# Altium schematic sheet Memory.SchDoc(Memory)
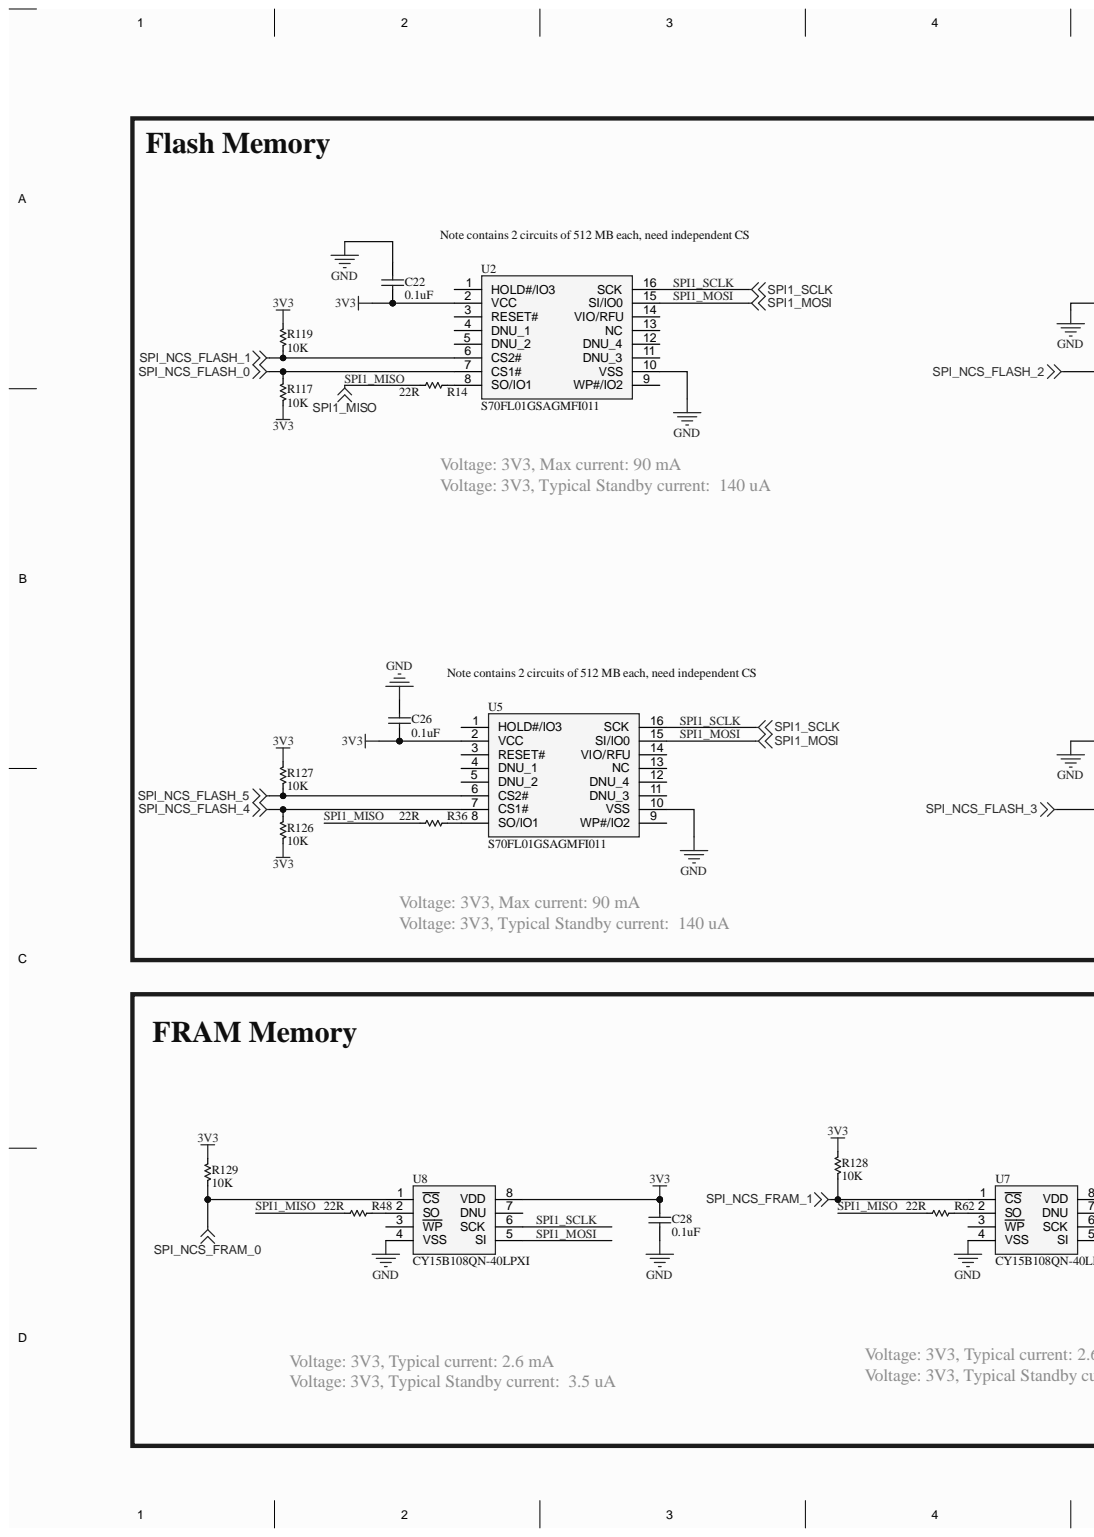
[diagram p0: Memory.SchDoc, left]
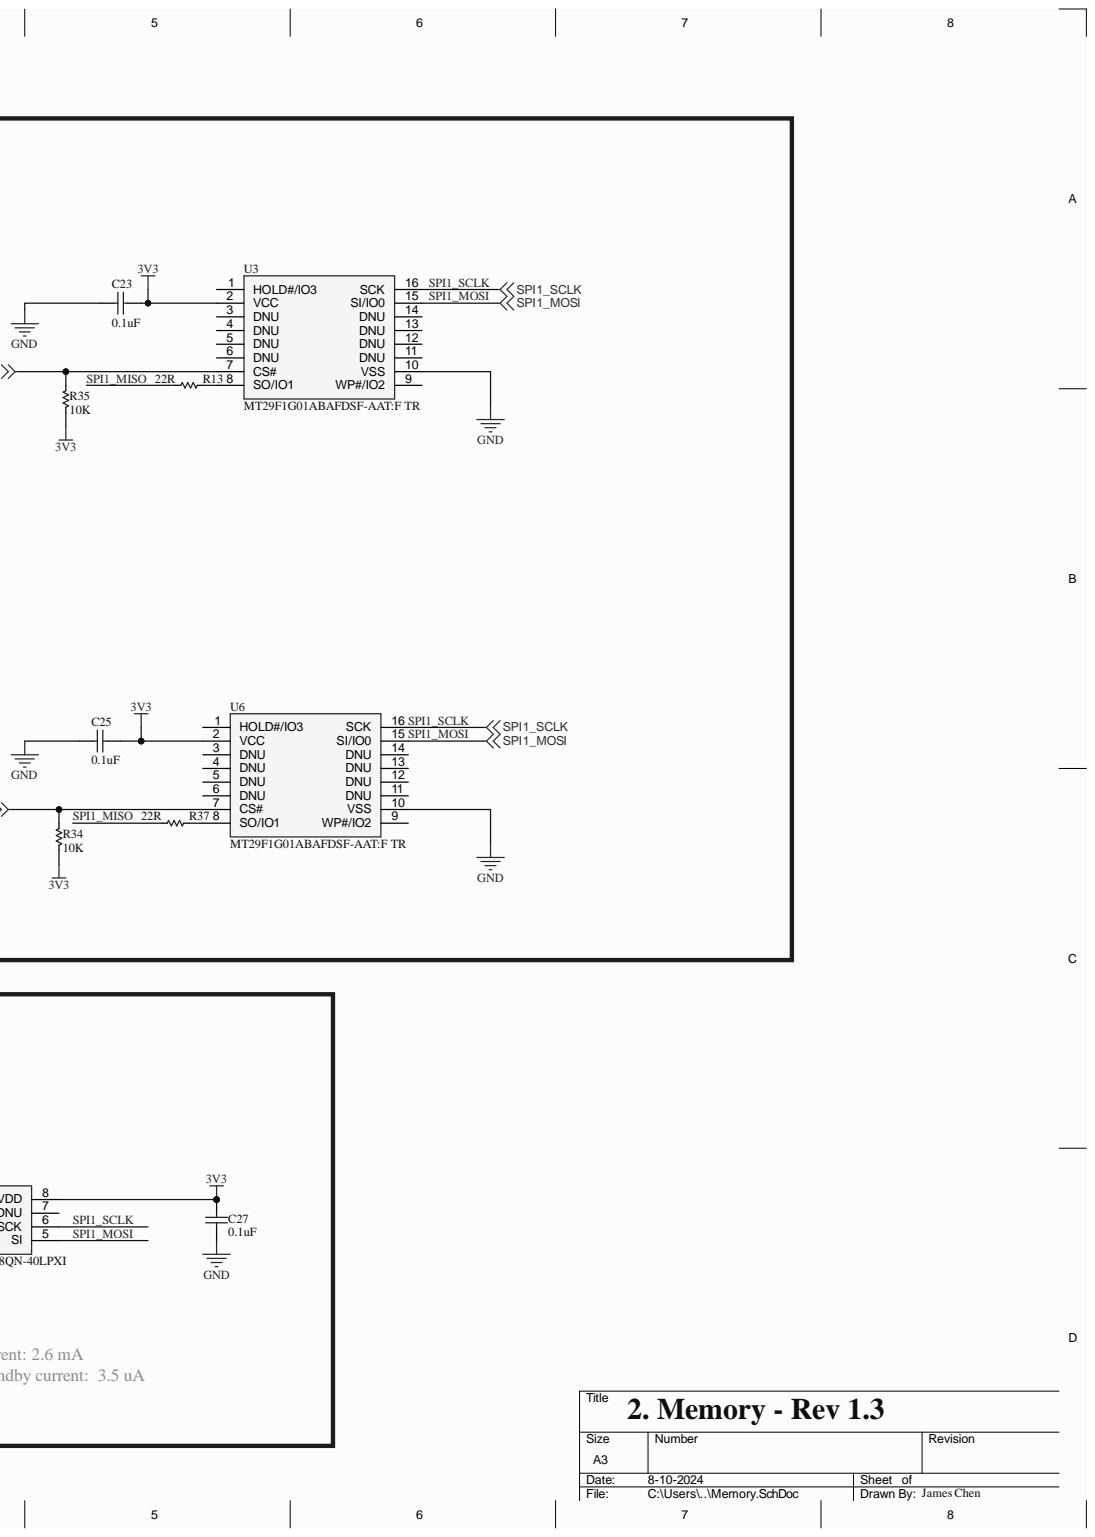
[diagram p1: Memory.SchDoc, right]

## Parts
C22  0.1uF  [0603]
C23  0.1uF  [0603]
C25  0.1uF  [0603]
C26  0.1uF  [0603]
C27  0.1uF  [0603]
C28  0.1uF  [0603]
R13  22R  [0603]
R14  22R  [0603]
R34  10K  [0603]
R35  10K  [0603]
R36  22R  [0603]
R37  22R  [0603]
R48  22R  [0603]
R62  22R  [0603]
R117  10K  [0603]
R119  10K  [0603]
R126  10K  [0603]
R127  10K  [0603]
R128  10K  [0603]
R129  10K  [0603]
U2  S70FL01GSAGMFI011  [U-S70FL01GSAGMFI011]
U3  MT29F1G01ABAFDSF-AAT:F TR  [U-MT29F1G01ABAFDSF-AAT:F TR]
U5  S70FL01GSAGMFI011  [U-S70FL01GSAGMFI011]
U6  MT29F1G01ABAFDSF-AAT:F TR  [U-MT29F1G01ABAFDSF-AAT:F TR]
U7  CY15B108QN-40LPXI  [U-CY15B108QN-40LPXI]
U8  CY15B108QN-40LPXI  [U-CY15B108QN-40LPXI]

## Nets
3V3: C22.1 C23.2 C25.2 C26.1 C27.1 C28.1 R34.1 R35.1 R117.1 R119.1 R126.1 R127.2 R128.1 R129.1 U2.2(VCC) U3.2(VCC) U5.2(VCC) U6.2(VCC) U7.8(VDD) U8.8(VDD)
GND: C22.2 C23.1 C25.1 C26.2 C27.2 C28.2 U2.10(VSS) U3.10(VSS) U5.10(VSS) U6.10(VSS) U7.4(VSS) U8.4(VSS)
SPI_NCS_FLASH_0: R117.2 U2.7(CS1#)
SPI_NCS_FLASH_1: R119.2 U2.6(CS2#)
SPI_NCS_FLASH_2: R35.2 U3.7(CS#)
SPI_NCS_FLASH_3: R34.2 U6.7(CS#)
SPI_NCS_FLASH_4: R126.2 U5.7(CS1#)
SPI_NCS_FLASH_5: R127.1 U5.6(CS2#)
SPI_NCS_FRAM_0: R129.2 U8.1(CS)
SPI_NCS_FRAM_1: R128.2 U7.1(CS)
SPI1_MISO: R13.1 R14.1 R36.1 R37.1 R48.1 R62.1
SPI1_MOSI: U2.15(SI/IO0) U3.15(SI/IO0) U5.15(SI/IO0) U6.15(SI/IO0) U7.5(SI) U8.5(SI)
SPI1_SCLK: U2.16(SCK) U3.16(SCK) U5.16(SCK) U6.16(SCK) U7.6(SCK) U8.6(SCK)
NetR13_2: R13.2 U3.8(SO/IO1)
NetR14_2: R14.2 U2.8(SO/IO1)
NetR36_2: R36.2 U5.8(SO/IO1)
NetR37_2: R37.2 U6.8(SO/IO1)
NetR48_2: R48.2 U8.2(SO)
NetR62_2: R62.2 U7.2(SO)

Not connected: U2 1(HOLD#/IO3) 3(RESET#) 4(DNU_1) 5(DNU_2) 9(WP#/IO2) 11(DNU_3) 12(DNU_4) 13(NC) 14(VIO/RFU); U3 1(HOLD#/IO3) 3(DNU) 4(DNU) 5(DNU) 6(DNU) 9(WP#/IO2) 11(DNU) 12(DNU) 13(DNU) 14(DNU); U5 1(HOLD#/IO3) 3(RESET#) 4(DNU_1) 5(DNU_2) 9(WP#/IO2) 11(DNU_3) 12(DNU_4) 13(NC) 14(VIO/RFU); U6 1(HOLD#/IO3) 3(DNU) 4(DNU) 5(DNU) 6(DNU) 9(WP#/IO2) 11(DNU) 12(DNU) 13(DNU) 14(DNU); U7 3(WP) 7(DNU); U8 3(WP) 7(DNU)

## Library data of the parts
C22 C23 C25-C28 = CC-100nF-25V-X7R-0603: 25V 100nF X7R ±10% 0603 Multilayer Ceramic Capacitors MLCC - SMD/SMT ROHS; Manufacturer: Murata Electronics; Manufacturer Part #: GCJ188R71E104KA12D; Max Exposed Voltage: 6; Rated Voltage: 25; Component Kind: Standard; ComponentLink1description: Datasheet; ComponentLink1URL: https://ds.yuden.co.jp/TYCOMPAS/ut/detail?pn=TMK105BJ104KV-F%20%20&u=M; ComponentLink2description: Manufacturer Website; ComponentLink2URL: https://www.yuden.co.jp/or/; Distributor: LCSC; Distributor Part #: C354391; Highest Rated Operating Temperature: 125; Lowest Rated Operating Temperature: -55; Part Description: 25V 100nF X7R ±10% 0603 Multilayer Ceramic Capacitors MLCC - SMD/SMT ROHS; Value: 0.1uF; Library Name: OBC Development Board.SchLib
R13 R14 R36 R37 R48 R62 = RT-22R0-F-1/16W-0603: 22 Ohms ±0.5% 0.063W, 1/16W Chip Resistor 0603 (1608 Metric) - Thin Film; Manufacturer: Susumu; Manufacturer Part #: RR0816Q-220-D; Component Kind: Standard; ComponentLink1description: Datasheet; ComponentLink1URL: https://www.vishay.com/docs/20035/dcrcwe3.pdf; ComponentLink2description: Manufacturer Website; ComponentLink2URL: https://www.vishay.com/; Distributor: Digikey; Distributor Part #: RR08Q22DCT-ND; Part Description: 22 Ohms ±0.5% 0.063W, 1/16W Chip Resistor 0603 (1608 Metric) - Thin Film; Value: 22R; Library Name: OBC Development Board.SchLib
R34 R35 R117 R119 R126-R129 = RT-10K0-F-1/16W-0603: 10 kOhms ±0.1% 0.063W, 1/16W Chip Resistor 0603 (1608 Metric) - Thin Film; Manufacturer: TE Connectivity Passive Product; Manufacturer Part #: CPF0603B10KE; Component Kind: Standard; ComponentLink1description: Datasheet; ComponentLink1URL: https://www.vishay.com/docs/20035/dcrcwe3.pdf; ComponentLink2description: Manufacturer Website; ComponentLink2URL: https://www.vishay.com/; Distributor: Digikey; Distributor Part #: A119886CT-ND; Part Description: 10 kOhms ±0.1% 0.063W, 1/16W Chip Resistor 0603 (1608 Metric) - Thin Film; Value: 10K; Library Name: OBC Development Board.SchLib
U2 U5 = U-S70FL01GSAGMFI011: IC FLASH 1GBIT SPI/QUAD 16SOIC; Manufacturer: Cypress Semiconductor; Component Kind: Standard; Distributor: Digikey; Distributor Part #: 1274-1092-ND; LCSC Part #: C476475; Manufacturer Part #: S70FL01GSAGMFI011; Library Name: OBC Development Board.SchLib
U3 U6 = U-MT29F1G01ABAFDSF-AAT:F TR: IC FLASH 1GBIT SPI 16SO; Manufacturer: Micron Technology Inc.; Component Kind: Standard; ComponentLink1Description: Part Link; ComponentLink1URL: https://www.digikey.ca/en/products/detail/micron-technology-inc/MT29F1G01ABAFDSF-AAT-F-TR/6135560; ComponentLink2Description: Datasheet; ComponentLink2URL: https://www.farnell.com/datasheets/3151163.pdf; Distributer: Digikey; Distributer Part #: 557-1653-1-ND; Manufacturer Part #: MT29F1G01ABAFDSF-AAT:F TR; Library Name: OBC Development Board.SchLib
U7 U8 = U-CY15B108QN-40LPXI: IC FRAM 8MBIT SPI 40MHZ 8GQFN; Manufacturer: Cypress Semiconductor; Component Kind: Standard; Distributor: Digikey; Distributor Part #: 428-4723-ND; Manufacturer Part #: CY15B108QN-40LPXI; Library Name: OBC Development Board.SchLib
